# Altium schematic sheet SIM_SD-Card_onnector.SchDoc
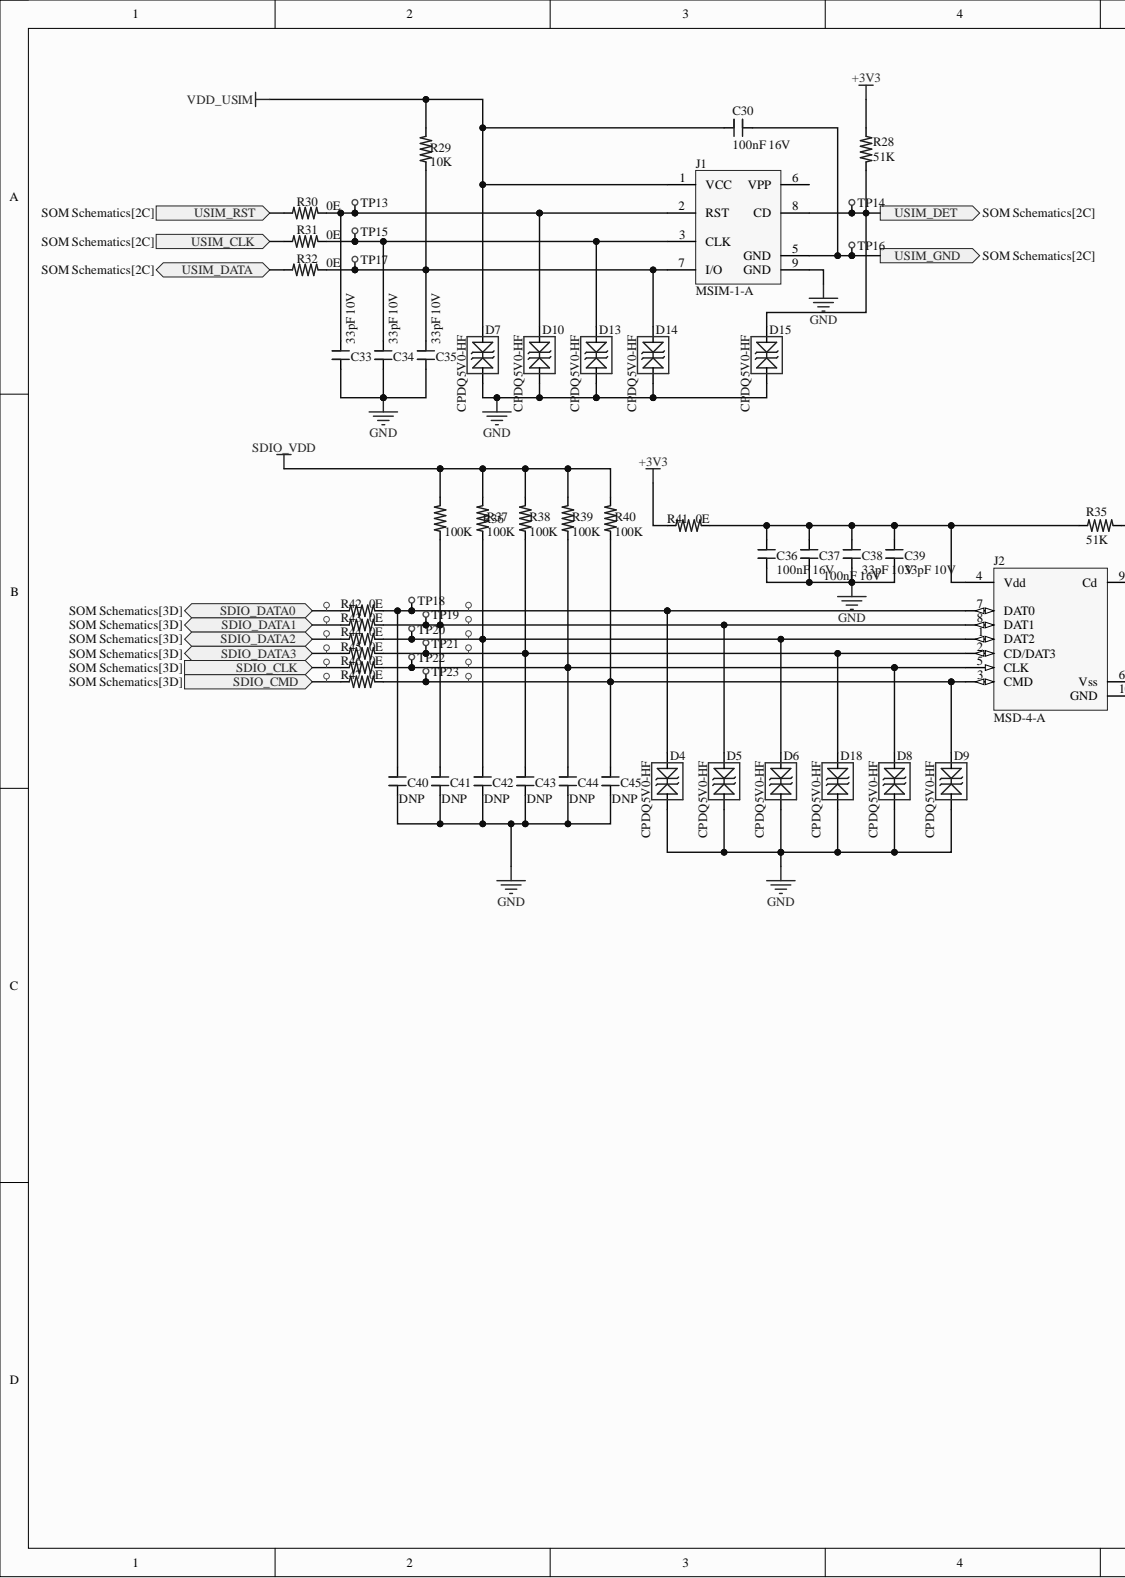
[diagram p0: SIM_SD-Card_onnector.SchDoc, left]
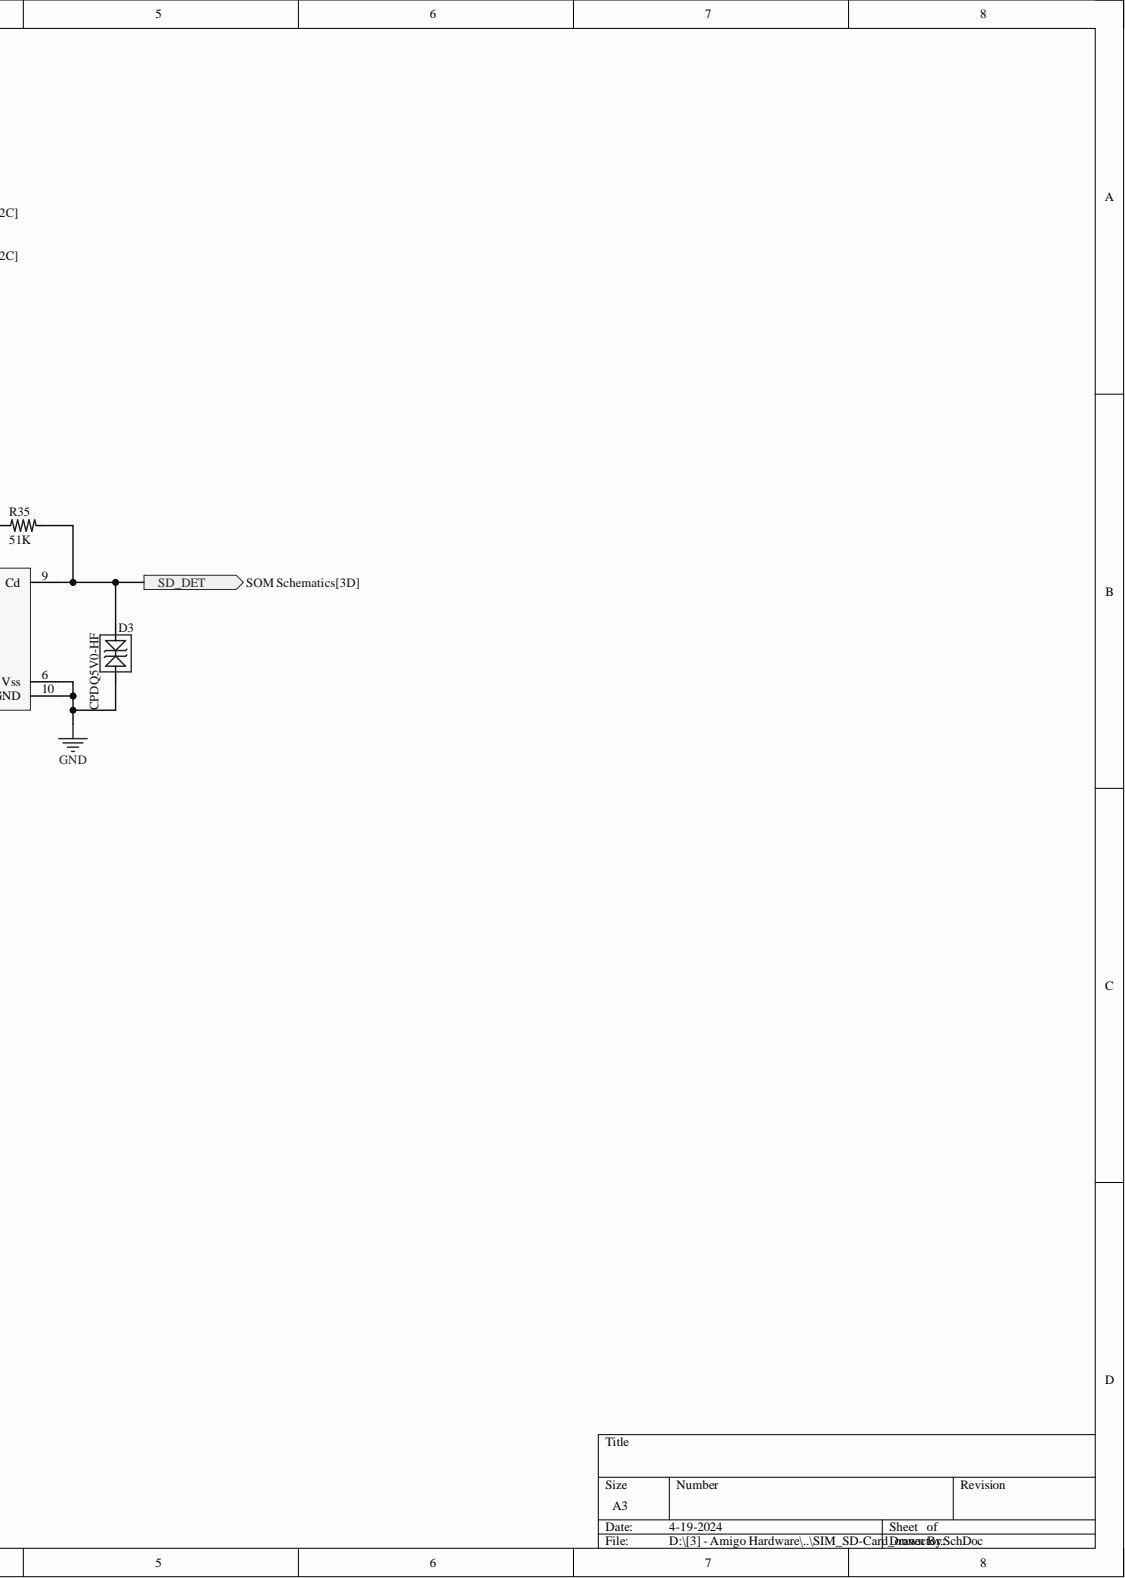
[diagram p1: SIM_SD-Card_onnector.SchDoc, right]

## Parts
C30  100nF 16V  value 100nF  Rated DC Voltage (URdc): 16V  [CAP_0603]
C33  33pF 10V  [CAP_0603]
C34  33pF 10V  [CAP_0603]
C35  33pF 10V  [CAP_0603]
C36  100nF 16V  value 100nF  [CAP_0603]
C37  100nF 16V  value 100nF  Rated DC Voltage (URdc): 16V  [CAP_0603]
C38  33pF 10V  [CAP_0603]
C39  33pF 10V  [CAP_0603]
C40  DNP  [CAP_0603]  (no BOM)
C41  DNP  [CAP_0603]  (no BOM)
C42  DNP  [CAP_0603]  (no BOM)
C43  DNP  [CAP_0603]  (no BOM)
C44  DNP  [CAP_0603]  (no BOM)
C45  DNP  [CAP_0603]  (no BOM)
D3  CPDQ5V0-HF  [SOD-923F]
D4  CPDQ5V0-HF  [SOD-923F]
D5  CPDQ5V0-HF  [SOD-923F]
D6  CPDQ5V0-HF  [SOD-923F]
D7  CPDQ5V0-HF  [SOD-923F]
D8  CPDQ5V0-HF  [SOD-923F]
D9  CPDQ5V0-HF  [SOD-923F]
D10  CPDQ5V0-HF  [SOD-923F]
D13  CPDQ5V0-HF  [SOD-923F]
D14  CPDQ5V0-HF  [SOD-923F]
D15  CPDQ5V0-HF  [SOD-923F]
D18  CPDQ5V0-HF  [SOD-923F]
J1  MSIM-1-A  [CON_MSIM_7POS]
J2  MSD-4-A  [CON_SD_CARD_9POS_1.1mm-P_1ROW]
R28  51K  [RES_0603]
R29  10K  [RES_0603]
R30  0E  [RES_0603]
R31  0E  [RES_0603]
R32  0E  [RES_0603]
R35  51K  [RES_0603]
R36  100K  [RES_0603]
R37  100K  [RES_0603]
R38  100K  [RES_0603]
R39  100K  [RES_0603]
R40  100K  [RES_0603]
R41  0E  [RES_0603]
R42  0E  [RES_0603]
R43  0E  [RES_0603]
R44  0E  [RES_0603]
R45  0E  [RES_0603]
R46  0E  [RES_0603]
R47  0E  [RES_0603]
TP13  Test Point SMD Round 0.8mm  [Test Point Round 0.8mm]  (value not drawn)
TP14  Test Point SMD Round 0.8mm  [Test Point Round 0.8mm]  (value not drawn)
TP15  Test Point SMD Round 0.8mm  [Test Point Round 0.8mm]  (value not drawn)
TP16  Test Point SMD Round 0.8mm  [Test Point Round 0.8mm]  (value not drawn)
TP17  Test Point SMD Round 0.8mm  [Test Point Round 0.8mm]  (value not drawn)
TP18  Test Point SMD Round 0.8mm  [Test Point Round 0.8mm]  (value not drawn)
TP19  Test Point SMD Round 0.8mm  [Test Point Round 0.8mm]  (value not drawn)
TP20  Test Point SMD Round 0.8mm  [Test Point Round 0.8mm]  (value not drawn)
TP21  Test Point SMD Round 0.8mm  [Test Point Round 0.8mm]  (value not drawn)
TP22  Test Point SMD Round 0.8mm  [Test Point Round 0.8mm]  (value not drawn)
TP23  Test Point SMD Round 0.8mm  [Test Point Round 0.8mm]  (value not drawn)

## Ports
SD_DET, SDIO_CLK, SDIO_CMD, SDIO_DATA0, SDIO_DATA1, SDIO_DATA2, SDIO_DATA3, USIM_CLK, USIM_DATA, USIM_DET, USIM_GND, USIM_RST

## Nets
+3V3: R28.1 R41.1
GND: C33.1 C34.1 C35.1 C36.1 C37.1 C38.1 C39.1 C40.1 C41.1 C42.1 C43.1 C44.1 C45.1 D3.1 D4.1 D5.1 D6.1 D7.1 D8.1 D9.1 D10.1 D13.1 D14.1 D15.1 D18.1 J1.9(GND) J2.6(Vss) J2.10(GND)
SDIO_VDD: R36.2 R37.2 R38.2 R39.2 R40.2
VDD_USIM: C30.1 D7.2 J1.1(VCC) R29.2
SD_DET: D3.2 J2.9(Cd) R35.2 ; port SD_DET
SDIO_CLK: R46.1 ; port SDIO_CLK
SDIO_CMD: R47.1 ; port SDIO_CMD
SDIO_DATA0: R42.1 ; port SDIO_DATA0
SDIO_DATA1: R43.1 ; port SDIO_DATA1
SDIO_DATA2: R44.1 ; port SDIO_DATA2
SDIO_DATA3: R45.1 ; port SDIO_DATA3
USIM_CLK: R31.1 ; port USIM_CLK
USIM_DATA: R32.1 ; port USIM_DATA
USIM_DET: D15.2 J1.8(CD) R28.2 TP14.1 ; port USIM_DET
USIM_GND: C30.2 J1.5(GND) TP16.1 ; port USIM_GND
USIM_RST: R30.1 ; port USIM_RST
NetC33_2: C33.2 D10.2 J1.2(RST) R30.2 TP13.1
NetC34_2: C34.2 D13.2 J1.3(CLK) R31.2 TP15.1
NetC35_2: C35.2 D14.2 J1.7(I/O) R29.1 R32.2 TP17.1
NetC36_2: C36.2 C37.2 C38.2 C39.2 J2.4(Vdd) R35.1 R41.2
NetC40_2: C40.2 D4.2 J2.7(DAT0) R42.2 TP18.1
NetC41_2: C41.2 D5.2 J2.8(DAT1) R36.1 R43.2 TP19.1
NetC42_2: C42.2 D6.2 J2.1(DAT2) R37.1 R44.2 TP20.1
NetC43_2: C43.2 D18.2 J2.2(CD/DAT3) R38.1 R45.2 TP21.1
NetC44_2: C44.2 D8.2 J2.5(CLK) R39.1 R46.2 TP22.1
NetC45_2: C45.2 D9.2 J2.3(CMD) R40.1 R47.2 TP23.1

Not connected: J1 6(VPP)

## Library data of the parts
C30 C36 C37 = CAP_100nF_16V_10%_X7R_0603: Ceramic Capacitor, Multilayer, Ceramic, 16V, 10% +Tol, 10% -Tol, X7R, 15% TC, 0.1uF, Surface Mount, 0603; Manufacturer: KEMET; Manufacturer Part Number: C0603C104K4RACTU; Multilayer: Yes; Capacitor Type: Ceramic; Case/Package: 0603; Category: Ceramic Capacitors; Component Kind: Standard; ComponentLink1Description: https://datasheet.ciiva.com:443/pdfs/VipMasterIC/IC/KEME/KEME-S-A0008991654/KEME-S-A0008991654-1.pdf?src-supplier=IHS+Markit; ComponentLink1URL: https://datasheet.ciiva.com:443/pdfs/VipMasterIC/IC/KEME/KEME-S-A0008991654/KEME-S-A0008991654-1.pdf?src-supplier=IHS+Markit; ComponentLink2Description: https://datasheet.ciiva.com/22749/kem-c1002-x7r-smd-22749657.pdf?src-supplier=Element14; ComponentLink2URL: https://datasheet.ciiva.com/22749/kem-c1002-x7r-smd-22749657.pdf?src-supplier=Element14; ComponentLink3Description: https://datasheet.ciiva.com/14589/70095383-14589014.pdf?src-supplier=Allied+Electronics; ComponentLink3URL: https://datasheet.ciiva.com/14589/70095383-14589014.pdf?src-supplier=Allied+Electronics; ComponentLink4Description: https://datasheet.ciiva.com/5413/kem-c1002-x7r-smd-5413791.pdf?src-supplier=Mouser; ComponentLink4URL: https://datasheet.ciiva.com/5413/kem-c1002-x7r-smd-5413791.pdf?src-supplier=Mouser; Dielectric Material: Ceramic; Height: 0.8mm; JESD-609 Code: e3; Length: 1.6mm; Library Name: EM_LIBRARY_1.IntLib; Max Operating Temperature: 125°C; Min Operating Temperature: -55°C; Mounting Technology: Surface Mount; Package Shape: Rectangular; Package Style: SMTMeter; Packaging: Tape and Reel; Pins: 2; Pricing: 1=0.1, 10=0.069, 50=0.0394, 100=0.0305, 500=0.02298, 1000=0.01805 (USD); Reach SVHC Compliant: Yes; RoHS: Yes; RoHS Compliant: Yes; Stock: 507245; Supplier: Digi-Key; Supplier Part Number: 399-C0603C104K4RAC7867DKR-ND; Temperature Characteristics Code: X7R; Terminal Finish: Nickel; Termination: Wraparound; Tolerance  (fill in as +-): 10%; Tolerance (fill in as +-): 10%; Width: 0.8mm
  C36: Rated DC Voltage (URdc): 16V
C33-C35 C38 C39 = CAP_33pF_10V_2%_X8R_0603: 33 pF ±2% 10V Ceramic Capacitor X8R 0603 (1608 Metric); Manufacturer: KEMET; Manufacturer Part Number: C0603C330G8HAC7867; Category: Ceramic Capacitors; Component Kind: Standard; Library Name: EM_LIBRARY_1.IntLib; Pricing: 1=0.1, 10=0.069, 50=0.0394, 100=0.0305, 500=0.02298, 1000=0.01805 (USD); RoHS: No; Stock: 13798; Supplier: Digi-Key; Supplier Part Number: 399-C0603C330G8HAC7867DKR-ND
C40-C45 = CAP_NOT-MOUNTED_0603: Do Not Place this Capacitor 0603; Manufacturer: KEMET; Manufacturer Part Number: C0603C103K8RACTU; Capacitance: 10nF; Dielectric: X7R; Dielectric Material: Ceramic; Dissipation Factor: 5%; Tolerance: 10%; Voltage Rating: 10V; Case Code (Imperial): 0603; Case Code (Metric): 1608; Case/Package: 0603; Category: Ceramic Capacitors; ComponentLink1Description: https://datasheet.ciiva.com/49516/1564916-49516523.pdf?src-supplier=Jameco; ComponentLink1URL: https://datasheet.ciiva.com/49516/1564916-49516523.pdf?src-supplier=Jameco; Construction: Flat; Depth: 0.8mm; Height: 0.8mm; Lead Pitch: 0.7mm; Length: 1.6mm; Library Name: EM_LIBRARY_1.IntLib; Manufacturer 1: KEMET; Manufacturer Part Number 1: C0603C103K8RACTU; Max Operating Temperature: 125°C; Min Operating Temperature: -55°C; Mount: Surface Mount; Package Quantity: 1; Packaging: Tape and Reel; Pricing 1: 1=0.1, 10=0.034, 50=0.034, 100=0.02, 1000=0.016, 10000=0.011 (USD); Reach SVHC Compliant: No; RoHS: Yes; Series: -; Stock 1: 6134; Supplier 1: Mouser; Supplier Part Number 1: 80-C0603C103K8R; Supplier-1: Digikey; Termination: SMD/SMT; Thickness: 0.034inch; Voltage Rating (DC): 10V; Width: 0.031inch
D3-D10 D13-D15 D18 = DIO-TVS_5.6V Vbr_18.6V Vc_9.4A Ip_SOD-923: ESD Suppressor Diode TVS Bi-Dir 5V 18.6Vc 2-Pin SOD-923F T/R; Manufacturer: Comchip; Breakdown Voltage: 5.6V; Capacitance: 15pF; Clamping Voltage: 7.3V; Diode Type: ZENER; Number of Bidirectional Channels: 1; Peak Pulse Current: 9.4A; Peak Pulse Power: 174W; Power Line Protection: No; Case/Package: 0402; Category: TVS Diodes; Component Kind: Standard; ComponentLink1Description: https://datasheet.ciiva.com/6577/cpdq5v0-hf20reva825126-6577872.pdf?src-supplier=Digi-Key; ComponentLink1URL: https://datasheet.ciiva.com/6577/cpdq5v0-hf20reva825126-6577872.pdf?src-supplier=Digi-Key; ComponentLink2Description: https://datasheet.ciiva.com/12545/cpdq5v0-hf20reva825126-218795-12545530.pdf?src-supplier=Mouser; ComponentLink2URL: https://datasheet.ciiva.com/12545/cpdq5v0-hf20reva825126-218795-12545530.pdf?src-supplier=Mouser; Library Name: EM_LIBRARY_1.IntLib; Manufacturer 2: Comchip; Manufacturer Part Number: CPDQ5V0-HF; Manufacturer Part Number 2: CPDQ5V0-HF; Max Operating Temperature: 125°C; Min Breakdown Voltage: 5.6V; Min Operating Temperature: -40°C; Mount: Surface Mount; Operating Supply Voltage: 5V; Package Quantity: 8000; Packaging: Tape and Reel; Pricing 1: 1=0.31, 10=0.252, 100=0.1337, 500=0.08794, 1000=0.0598, 2000=0.05394 (USD); Pricing 2: 1=0.31, 10=0.214, 100=0.1042, 500=0.08692, 1000=0.0604, 2000=0.05235 (USD); Reverse Standoff Voltage: 5V; RoHS: Yes; RoHS Compliant: Yes; Series: -; Stock 1: 81421; Stock 2: 80696; Supplier 1: Digi-Key; Supplier 2: Digi-Key; Supplier Part Number 2: 641-1478-1-ND
J1 = COM_MSIM_7POS_CUI: Memory Card Connectors 7 Positions, Push In, Auto Eject Out, SMT, 1.37 mm Height Above Board,; Component Kind: Standard; ComponentLink1Description: LCSC Link; ComponentLink1URL: https://www.lcsc.com/product-detail/SIM-Card-Connectors_SHOU-HAN-MICRO-SIM-7P-H1-35_C7529381.html; Library Name: EM_LIBRARY_1.IntLib
J2 = CON_SD-Card_TF_card_H1.8_Push_Pull_SMD: Memory Card Connectors 9 Positions, Push In, Auto Eject Out, SMT, 1.8 mm Height Above Board,; Manufacturer: SHOU HAN; Manufacturer Part Number: TF-CARDH1.8; Category: Uncategorized; Component Kind: Standard; ComponentLink6Description: https://datasheet.ciiva.com/683/1040310811-sd-683581.pdf?src-supplier=Digi-Key; ComponentLink6URL: https://datasheet.ciiva.com/683/1040310811-sd-683581.pdf?src-supplier=Digi-Key; ComponentLink7Description: https://datasheet.ciiva.com/15174/getdatasheetpartid-716154-15174887.pdf?src-supplier=Verical; ComponentLink7URL: https://datasheet.ciiva.com/15174/getdatasheetpartid-716154-15174887.pdf?src-supplier=Verical; Library Name: EM_LIBRARY_1.IntLib; Pricing: 1=0.35, 10=0.348, 25=0.3408, 50=0.3204, 100=0.2727, 250=0.25224, 500=0.24544 (USD); RoHS: No; Stock: 1616; Supplier: LCSC; Supplier Part Number: C7529389
R28 R35 = RES_51K_0.1W_1%_0603: Fixed Resistor, Metal Glaze/thick Film, 0.1W, 51000ohm, 75V, 1% +/-Tol, 100ppm/Cel, Surface Mount, 0603; Manufacturer: Yageo; Manufacturer Part Number: RC0603FR-0751KL; Additional Feature: LASER TRIMMABLE; Package Height: 0.45mm; Package Length: 1.6mm; Package Width: 0.8mm; Power: 100mW; Rated Temperature: 70°C; Temperature Coefficient: 100ppm/°C; Value: 51kR; Case/Package: 0603; Category: Chip SMD Resistors; Component Kind: Standard; ComponentLink1Description: https://datasheet.ciiva.com:443/pdfs/VipMasterIC/IC/YAGO/YAGO-S-A0008145938/YAGO-S-A0008145938-1.pdf?src-supplier=IHS+Markit; ComponentLink1URL: https://datasheet.ciiva.com:443/pdfs/VipMasterIC/IC/YAGO/YAGO-S-A0008145938/YAGO-S-A0008145938-1.pdf?src-supplier=IHS+Markit; ComponentLink2Description: https://datasheet.ciiva.com/11819/0900766b80f96399-11819378.pdf?src-supplier=Rs; ComponentLink2URL: https://datasheet.ciiva.com/11819/0900766b80f96399-11819378.pdf?src-supplier=Rs; ComponentLink3Description: https://datasheet.ciiva.com/46395/443971-46395014.pdf?src-supplier=Element14; ComponentLink3URL: https://datasheet.ciiva.com/46395/443971-46395014.pdf?src-supplier=Element14; ComponentLink4Description: https://datasheet.ciiva.com/2454/pyu-r-int-thick-7-2454124.pdf?src-supplier=Digi-Key; ComponentLink4URL: https://datasheet.ciiva.com/2454/pyu-r-int-thick-7-2454124.pdf?src-supplier=Digi-Key; ComponentLink5Description: https://datasheet.ciiva.com/2454/pyu-r-int-thick-7-2454125.pdf?src-supplier=Digi-Key; ComponentLink5URL: https://datasheet.ciiva.com/2454/pyu-r-int-thick-7-2454125.pdf?src-supplier=Digi-Key; ComponentLink6Description: https://datasheet.ciiva.com/2454/pyu-r-int-thick-7-2454123.pdf?src-supplier=Digi-Key; ComponentLink6URL: https://datasheet.ciiva.com/2454/pyu-r-int-thick-7-2454123.pdf?src-supplier=Digi-Key; ComponentLink7Description: https://datasheet.ciiva.com/12558/rc0603-272842-12558554.pdf?src-supplier=Mouser; ComponentLink7URL: https://datasheet.ciiva.com/12558/rc0603-272842-12558554.pdf?src-supplier=Mouser; Construction: Chip; JESD-609 Code: e3; Library Name: EM_LIBRARY_1.IntLib; Max Operating Temperature: 155°C; Min Operating Temperature: -55°C; Mounting Technology: Surface Mount; Package Shape: Rectangular; Package Style: SMTMeter; Packaging: Tape and Reel; Pins: 2; Pricing: 1=0.1, 10=0.019, 50=0.0112, 100=0.0093, 500=0.00622, 1000=0.00532 (USD); Reach SVHC Compliant: Yes; Resistor Type: FIXED RESISTOR; RoHS: Yes; RoHS Compliant: Yes; Stock: 1453302; Supplier: Digi-Key; Supplier Part Number: 311-51.0KHRCT-ND; Technology: METAL GLAZE/THICK FILM; Terminal Finish: Nickel; Termination: Wraparound; Tolerance: 1%; Working Voltage: 75V
R29 = RES_10K_0.1W_1%_0603: Fixed Resistor, Metal Glaze/thick Film, 0.1W, 10000ohm, 75V, 1% +/-Tol, 100ppm/Cel, Surface Mount, 0603; Manufacturer: Yageo; Manufacturer Part Number: RC0603FR-0710KL; Additional Feature: LASER TRIMMABLE; Package Height: 0.45mm; Package Length: 1.6mm; Package Width: 0.8mm; Power: 100mW; Rated Temperature: 70°C; Temperature Coefficient: 100ppm/°C; Value: 10kR; Case/Package: 0603; Category: Chip SMD Resistors; Component Kind: Standard; ComponentLink1Description: https://datasheet.ciiva.com:443/pdfs/VipMasterIC/IC/YAGO/YAGO-S-A0008145938/YAGO-S-A0008145938-1.pdf?src-supplier=IHS+Markit; ComponentLink1URL: https://datasheet.ciiva.com:443/pdfs/VipMasterIC/IC/YAGO/YAGO-S-A0008145938/YAGO-S-A0008145938-1.pdf?src-supplier=IHS+Markit; ComponentLink2Description: https://datasheet.ciiva.com/46440/1868787-46440891.pdf?src-supplier=Element14; ComponentLink2URL: https://datasheet.ciiva.com/46440/1868787-46440891.pdf?src-supplier=Element14; ComponentLink3Description: https://datasheet.ciiva.com/7746/1738695-7746239.pdf?src-supplier=Element14; ComponentLink3URL: https://datasheet.ciiva.com/7746/1738695-7746239.pdf?src-supplier=Element14; ComponentLink4Description: https://datasheet.ciiva.com/7983/443971-7983596.pdf?src-supplier=Element14; ComponentLink4URL: https://datasheet.ciiva.com/7983/443971-7983596.pdf?src-supplier=Element14; ComponentLink5Description: https://datasheet.ciiva.com/7660/38590-7660789.pdf?src-supplier=Element14; ComponentLink5URL: https://datasheet.ciiva.com/7660/38590-7660789.pdf?src-supplier=Element14; ComponentLink6Description: https://datasheet.ciiva.com/22747/443971-22747519.pdf?src-supplier=Element14; ComponentLink6URL: https://datasheet.ciiva.com/22747/443971-22747519.pdf?src-supplier=Element14; ComponentLink7Description: https://datasheet.ciiva.com/15189/getdatasheetpartid-409289-15189591.pdf?src-supplier=Verical; ComponentLink7URL: https://datasheet.ciiva.com/15189/getdatasheetpartid-409289-15189591.pdf?src-supplier=Verical; Construction: Chip; JESD-609 Code: e3; Library Name: EM_LIBRARY_1.IntLib; Max Operating Temperature: 155°C; Min Operating Temperature: -55°C; Mounting Technology: Surface Mount; Package Shape: Rectangular; Package Style: SMTMeter; Packaging: Tape and Reel; Pins: 2; Pricing: 1=0.1, 10=0.019, 50=0.0112, 100=0.0093, 500=0.00622, 1000=0.00532 (USD); Reach SVHC Compliant: Yes; Resistor Type: FIXED RESISTOR; RoHS: Yes; RoHS Compliant: Yes; Stock: 24542638; Supplier: Digi-Key; Supplier Part Number: 311-10.0KHRDKR-ND; Technology: METAL GLAZE/THICK FILM; Terminal Finish: Nickel; Termination: Wraparound; Tolerance: 1%; Working Voltage: 75V
R30-R32 R41-R47 = RES_0E_0.1W_0603: Metal Glaze/thick Film, 0.1W, 0ohm, Surface Mount, 0603; Manufacturer: Yageo; Manufacturer Part Number: RC0603JR-070RL; Package Height: 0.45mm; Package Length: 1.6mm; Package Width: 0.8mm; Power: 100mW; Rated Temperature: 70°C; Value: 0R; Case/Package: 0603; Category: Chip SMD Resistors; Component Kind: Standard; ComponentLink1Description: https://datasheet.ciiva.com:443/pdfs/VipMasterIC/IC/YAGO/YAGO-S-A0008145938/YAGO-S-A0008145938-1.pdf?src-supplier=IHS+Markit; ComponentLink1URL: https://datasheet.ciiva.com:443/pdfs/VipMasterIC/IC/YAGO/YAGO-S-A0008145938/YAGO-S-A0008145938-1.pdf?src-supplier=IHS+Markit; Construction: Chip; JESD-609 Code: e3; Library Name: EM_LIBRARY_1.IntLib; Max Operating Temperature: 155°C; Min Operating Temperature: -55°C; Mounting Technology: Surface Mount; Package Shape: Rectangular; Package Style: SMTMeter; Packaging: Tape and Reel; Pins: 2; Pricing: 1=0.1, 10=0.015, 25=0.0112, 50=0.0086, 100=0.006, 250=0.0048, 500=0.00386, 1000=0.00284 (USD); Reach SVHC Compliant: Yes; Resistor Type: FIXED RESISTOR; RoHS: Yes; RoHS Compliant: Yes; Stock: 1005063; Supplier: Digi-Key; Supplier Part Number: 311-0.0GRCT-ND; Technology: METAL GLAZE/THICK FILM; Terminal Finish: Nickel; Termination: Wraparound
R36-R40 = RES_100K_0.1W_1%_0603: Fixed Resistor, Metal Glaze/thick Film, 0.1W, 100000ohm, 75V, 1% +/-Tol, 100ppm/Cel, Surface Mount, 0603; Manufacturer: Yageo; Manufacturer Part Number: RC0603FR-07100KL; Additional Feature: LASER TRIMMABLE; Package Height: 0.45mm; Package Length: 1.6mm; Package Width: 0.8mm; Power: 100mW; Rated Temperature: 70°C; Temperature Coefficient: 100ppm/°C; Value: 100kR; Case/Package: 0603; Category: Chip SMD Resistors; Component Kind: Standard; ComponentLink1Description: https://datasheet.ciiva.com:443/pdfs/VipMasterIC/IC/YAGO/YAGO-S-A0008145938/YAGO-S-A0008145938-1.pdf?src-supplier=IHS+Markit; ComponentLink1URL: https://datasheet.ciiva.com:443/pdfs/VipMasterIC/IC/YAGO/YAGO-S-A0008145938/YAGO-S-A0008145938-1.pdf?src-supplier=IHS+Markit; Construction: Chip; JESD-609 Code: e3; Library Name: EM_LIBRARY_1.IntLib; Max Operating Temperature: 155°C; Min Operating Temperature: -55°C; Mounting Technology: Surface Mount; Package Shape: Rectangular; Package Style: SMTMeter; Packaging: Tape and Reel; Pins: 2; Pricing: 1=0.1, 10=0.019, 50=0.0112, 100=0.0093, 500=0.00622, 1000=0.00532 (USD); Reach SVHC Compliant: Yes; Resistor Type: FIXED RESISTOR; RoHS: Yes; RoHS Compliant: Yes; Stock: 2631939; Supplier: Digi-Key; Supplier Part Number: 311-100KHRCT-ND; Technology: METAL GLAZE/THICK FILM; Terminal Finish: Nickel; Termination: Wraparound; Tolerance: 1%; Working Voltage: 75V
TP13-TP23 = Test Point SMD Round 0.8mm: Testpoint SMD Round; Component Kind: Net Tie; Library Name: EM_LIBRARY_1.IntLib
